# Altium schematic sheet Oxygen Sensor Amplifier.SchDoc("Oxygen Sensor Amplifier")
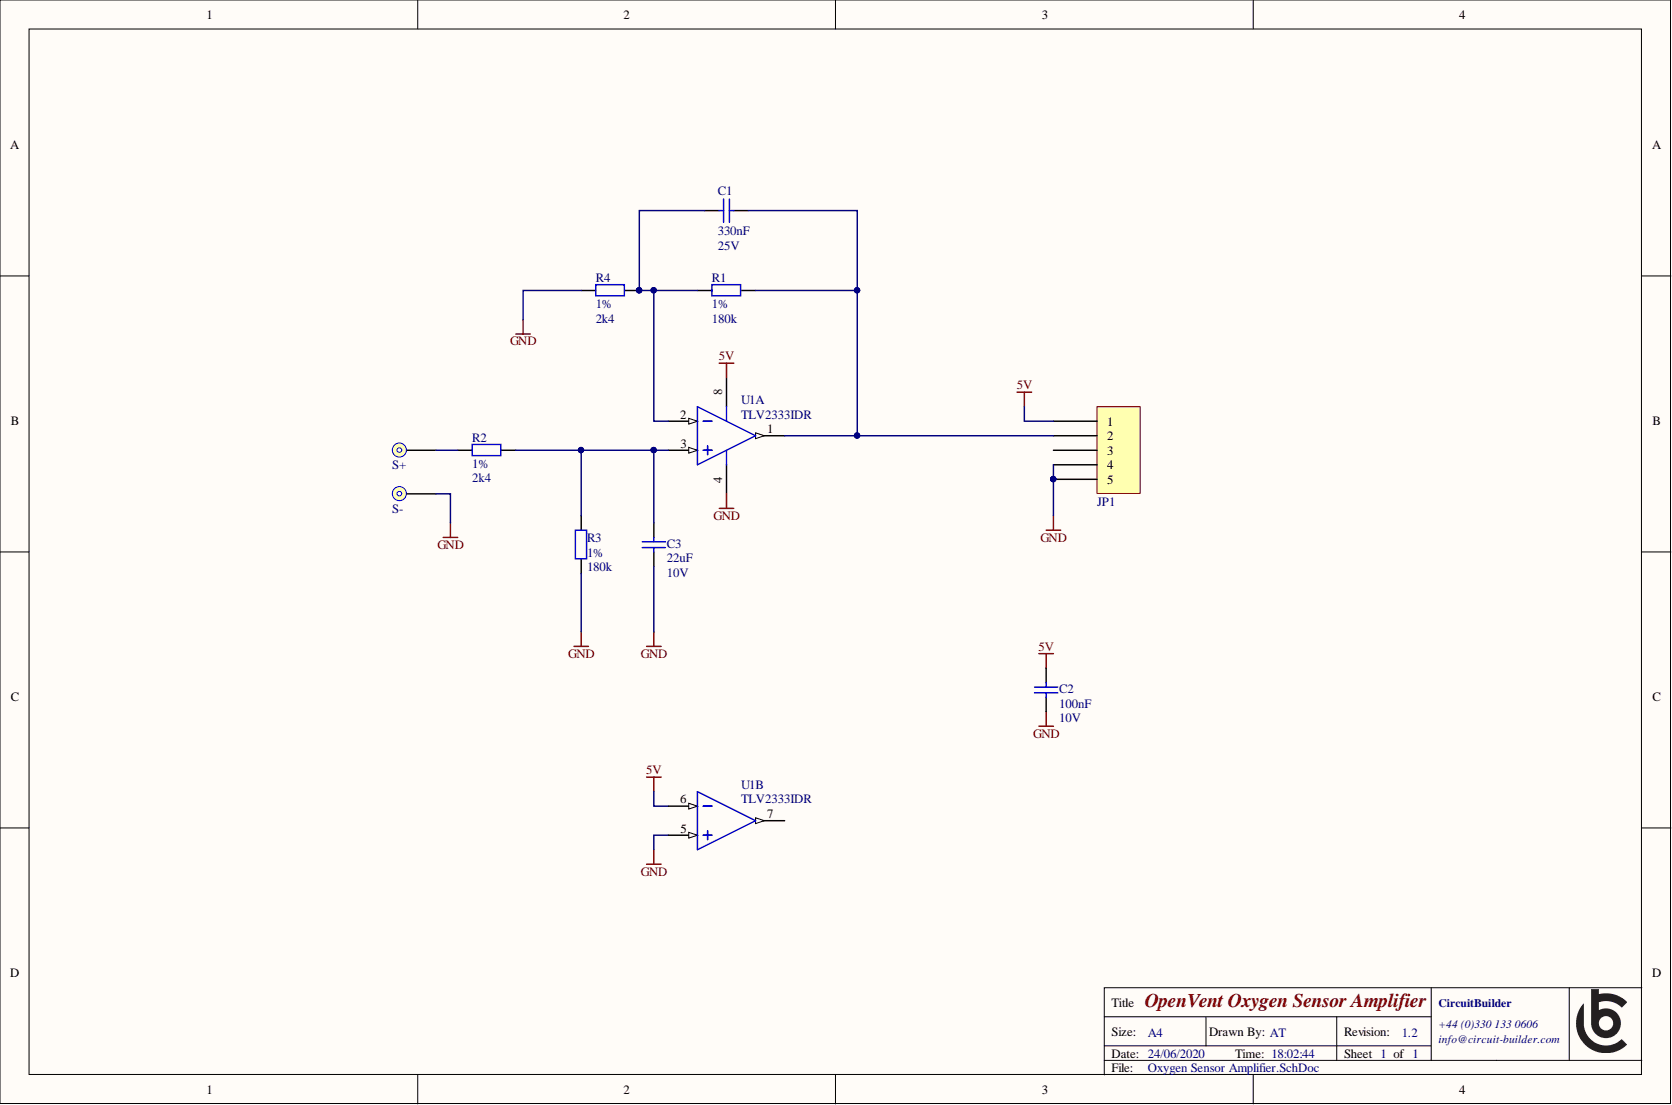
[diagram p0: Oxygen Sensor Amplifier.SchDoc]

## Parts
C1  value 330nF  Voltage: 25V  [C0603]  (hidden comment: 330nF 0603 Ceramic Capacitor, 10%, 25V)
C2  value 100nF  Voltage: 10V  [C0603]  (hidden comment: 100nF 0603 Ceramic Capacitor, 10%, X7R, 25V)
C3  value 22uF  Voltage: 10V  [C0603]  (hidden comment: 22uF 0603 Ceramic Capacitor, 10%, 10V)
JP1  5 Position Header Connector  Through Hole  [SOCKET 1x5]  (value not drawn)
R1  value 180k  Tolerance: 1%  [R0603]  (hidden comment: 180k 0603 Chip Resistor, 1%, 0.1W)
R2  value 2k4  Tolerance: 1%  [R0603]  (hidden comment: 2k4 0603 Chip Resistor, 1%, 0.1W)
R3  value 180k  Tolerance: 1%  [R0603]  (hidden comment: 180k 0603 Chip Resistor, 1%, 0.1W)
R4  value 2k4  Tolerance: 1%  [R0603]  (hidden comment: 2k4 0603 Chip Resistor, 1%, 0.1W)
S+  Test Point PTH  [Solder Point - 0.8mm Drill]  (value not drawn)  (no BOM)
S-  Test Point PTH  [Solder Point - 0.8mm Drill]  (value not drawn)  (no BOM)
U1  TLV2333IDR  [SO8_N]  (parts A,B)

## Nets
5V: C2.2 JP1.1 U1.6 U1.8
GND: C2.1 C3.2 JP1.4 JP1.5 R3.2 R4.2 S-.1 U1.4 U1.5
NetC1_1: C1.1 JP1.2 R1.1 U1.1
NetC1_2: C1.2 R1.2 R4.1 U1.2
NetC3_1: C3.1 R2.1 R3.1 U1.3
NetR2_2: R2.2 S+.1

Not connected: JP1 3; U1 7

## Library data of the parts
C1 = 100nF 0603 Ceramic Capacitor, 10%, X7R, 25V: ManufacturerPN: 0603B334K250NT; Manufacturer: Guangdong Fenghua Advanced Tech; ProductionCost: 0.0068; Cost-10000: 0.00417; Tolerance: 10%; Supplier2: Open Market; Supplier1PNProto: C1615; Supplier1PN: C1615; Supplier1: LCSC; Simulation: CAP; Signal Integrity: Cap; ProductionSupplierPN: C1615; ProductionSupplier: LCSC; Package: 0603; Library Name: Capacitors.SchLib; Description: 330nF 0603 Ceramic Capacitor, 10%, 25V; Cost: 0.08; Component Kind: Standard
C2 = 100nF 0603 Ceramic Capacitor, 10%, X7R, 25V: ManufacturerPN: CC0603KRX7R9BB104; Manufacturer: Yageo; ProductionCost: 0.0068; Cost-10000: 0.00417; Tolerance: 10%; Supplier2: Open Market; Supplier1PNProto: C14663; Supplier1PN: C14663; Supplier1: LCSC; Simulation: CAP; Signal Integrity: Cap; ProductionSupplierPN: C14663; ProductionSupplier: LCSC; Package: 0603; Library Name: Capacitors.SchLib; Description: 100nF 0603 Ceramic Capacitor, 10%, X7R, 25V; Cost: 0.08; Component Kind: Standard
C3 = 100nF 0603 Ceramic Capacitor, 10%, X7R, 25V: ManufacturerPN: CL10A226MP8NUNE; Manufacturer: Samsung Electro-Mechanics; ProductionCost: 0.0068; Cost-10000: 0.00417; Tolerance: 10%; Supplier2: Open Market; Supplier1PNProto: C86295; Supplier1PN: C86295; Supplier1: LCSC; Simulation: CAP; Signal Integrity: Cap; ProductionSupplierPN: C86295; ProductionSupplier: LCSC; Package: 0603; Library Name: Capacitors.SchLib; Description: 22uF 0603 Ceramic Capacitor, 10%, 10V; Cost: 0.08; Component Kind: Standard
JP1 = 5 Position Header Connector  Through Hole: Manufacturer: Sullins Connector Solutions; Supplier1PNProto: PPTC051LFBN-RC; Supplier1PN: PPTC051LFBN-RC; Supplier1: Digikey; Library Name: Connectors.SchLib; Description: 5 Position Header Connector  Through Hole; Cost: 0.38; Component Kind: Standard
R1 R3 = 100R 0603 Chip Resistor, 1%, 0.1W: ManufacturerPN: 0603WAF1803T5E; Manufacturer: Uniroyal Elec; ProductionCost: 0.0016; Cost-10000: 0.003; Supplier2: Open Market; Supplier1PNProto: C22827; Supplier1PN: C22827; Supplier1: LCSC; Simulation: RESISTOR; Signal Integrity: Res; ProductionSupplierPN: C22827; ProductionSupplier: LCSC; Library Name: Resistors.SchLib; Description: 180k 0603 Chip Resistor, 1%, 0.1W; Cost: 0.08; Component Kind: Standard
R2 R4 = 100R 0603 Chip Resistor, 1%, 0.1W: ManufacturerPN: 0603WAF2401T5E; Manufacturer: Uniroyal Elec; ProductionCost: 0.0016; Cost-10000: 0.003; Supplier2: Open Market; Supplier1PNProto: C22940; Supplier1PN: C22940; Supplier1: LCSC; Simulation: RESISTOR; Signal Integrity: Res; ProductionSupplierPN: C22940; ProductionSupplier: LCSC; Library Name: Resistors.SchLib; Description: 2k4 0603 Chip Resistor, 1%, 0.1W; Cost: 0.08; Component Kind: Standard
U1 = LM358E General Purpose Amplifier 2 Circuit 8-SOIC: Zero-Drift Amplifier 2 Circuit Rail-to-Rail 8-SOIC; Manufacturer: Texas Instruments; Supplier1PNProto: 296-43772-1-ND; Supplier1PN: 296-43772-1-ND; Supplier1: Digikey; Package: SOIC-8; ManufacturerPN: TLV2333IDR; Library Name: Op-Amps.SchLib; Component Kind: Standard; CreatedBy: AT
S+ S- = Test Point PTH: Library Name: Misc.SchLib
